# Altium schematic sheet J19B_EM3B_PP0_ADC.SchDoc
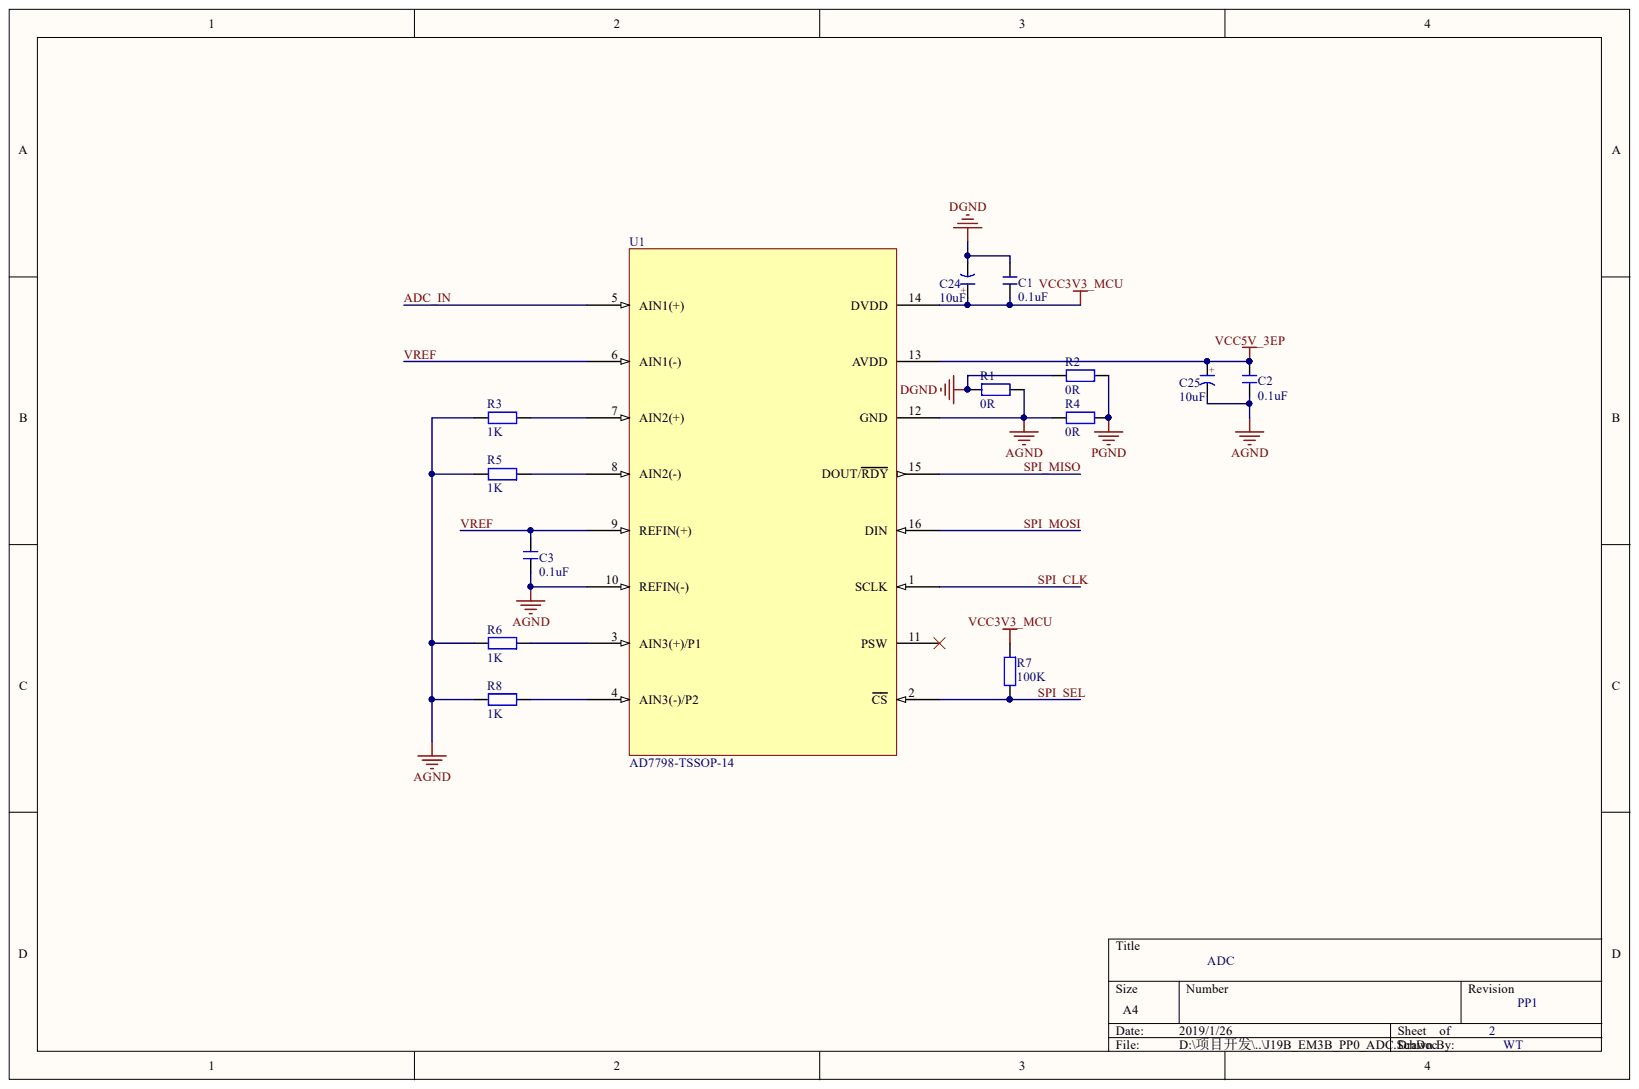
[diagram p0: J19B_EM3B_PP0_ADC.SchDoc]

## Parts
C1  0.1uF  [C 0603_L]
C2  0.1uF  [C 0603_L]
C3  0.1uF  [C 0603_L]
C24  10uF  [CD A(3216)]
C25  10uF  [CD A(3216)]
R1  0R  [R 0603_L]
R2  0R  [R 0603_L]
R3  1K  [R 0603_L]
R4  0R  [R 0603_L]
R5  1K  [R 0603_L]
R6  1K  [R 0603_L]
R7  100K  [R 0603_L]
R8  1K  [R 0603_L]
U1  AD7798-TSSOP-14  [TSSOP16_N]

## Nets
AGND: C2.2 C3.1 C25.2 R1.1 R3.1 R4.1 R5.1 R6.1 R8.1 U1.10(REFIN(-)) U1.12(GND)
DGND: C1.2 C24.2 R1.2 R2.1
PGND: R2.2 R4.2
VCC3V3_MCU: C1.1 C24.1 R7.2 U1.14(DVDD)
VCC5V_3EP: C2.1 C25.1 U1.13(AVDD)
ADC_IN: U1.5(AIN1(+))
SPI_CLK: U1.1(SCLK)
SPI_MISO: U1.15(DOUT/RDY)
SPI_MOSI: U1.16(DIN)
SPI_SEL: R7.1 U1.2(CS)
VREF: C3.2 U1.6(AIN1(-)) U1.9(REFIN(+))
NetR3_2: R3.2 U1.7(AIN2(+))
NetR5_2: R5.2 U1.8(AIN2(-))
NetR6_2: R6.2 U1.3(AIN3(+)/P1)
NetR8_2: R8.2 U1.4(AIN3(-)/P2)

Not connected: U1 11(PSW)

## Library data of the parts
C1-C3 = C: 无极性贴片电容; 版权归属: 源创客; BOM名称: 无极性贴片电容; Component Kind: Standard; Library Name: 3.1   - 电阻-电容-电感.SchLib; 学习资源: www.ooocke.com
C24 C25 = C-CD: 贴片钽电容; 版权归属: 源创客; BOM名称: 贴片钽电容; Component Kind: Standard; Library Name: 3.1   - 电阻-电容-电感.SchLib; 学习资源: www.ooocke.com
R1-R8 = R: 贴片电阻; 版权归属: 源创客; BOM名称: 贴片电阻; Component Kind: Standard; Library Name: 3.1   - 电阻-电容-电感.SchLib; 学习资源: www.ooocke.com
U1 = AD7798: Component Kind: Standard; Library Name: Z18B_EMSB_PP1.SchLib
